# Altium schematic sheet Micromouse_1_2.SchDoc
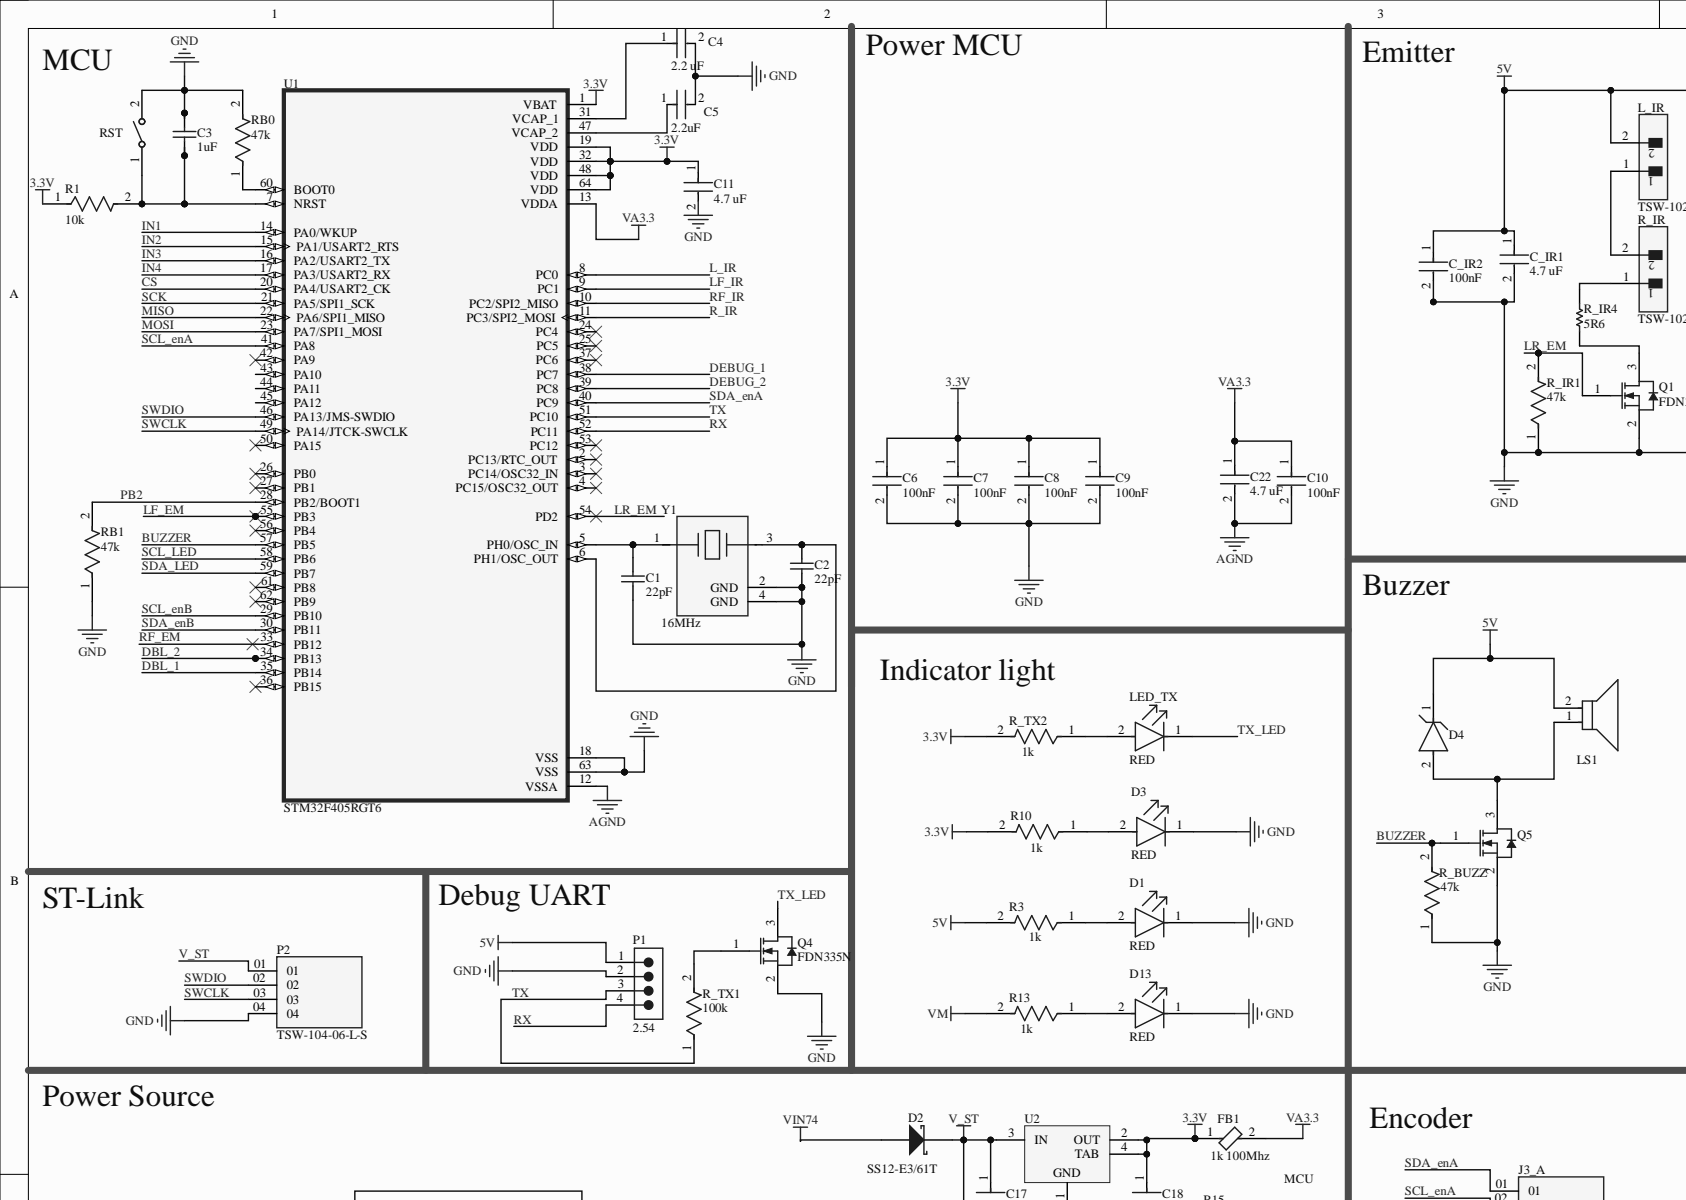
[diagram p0: Micromouse_1_2.SchDoc, top left]
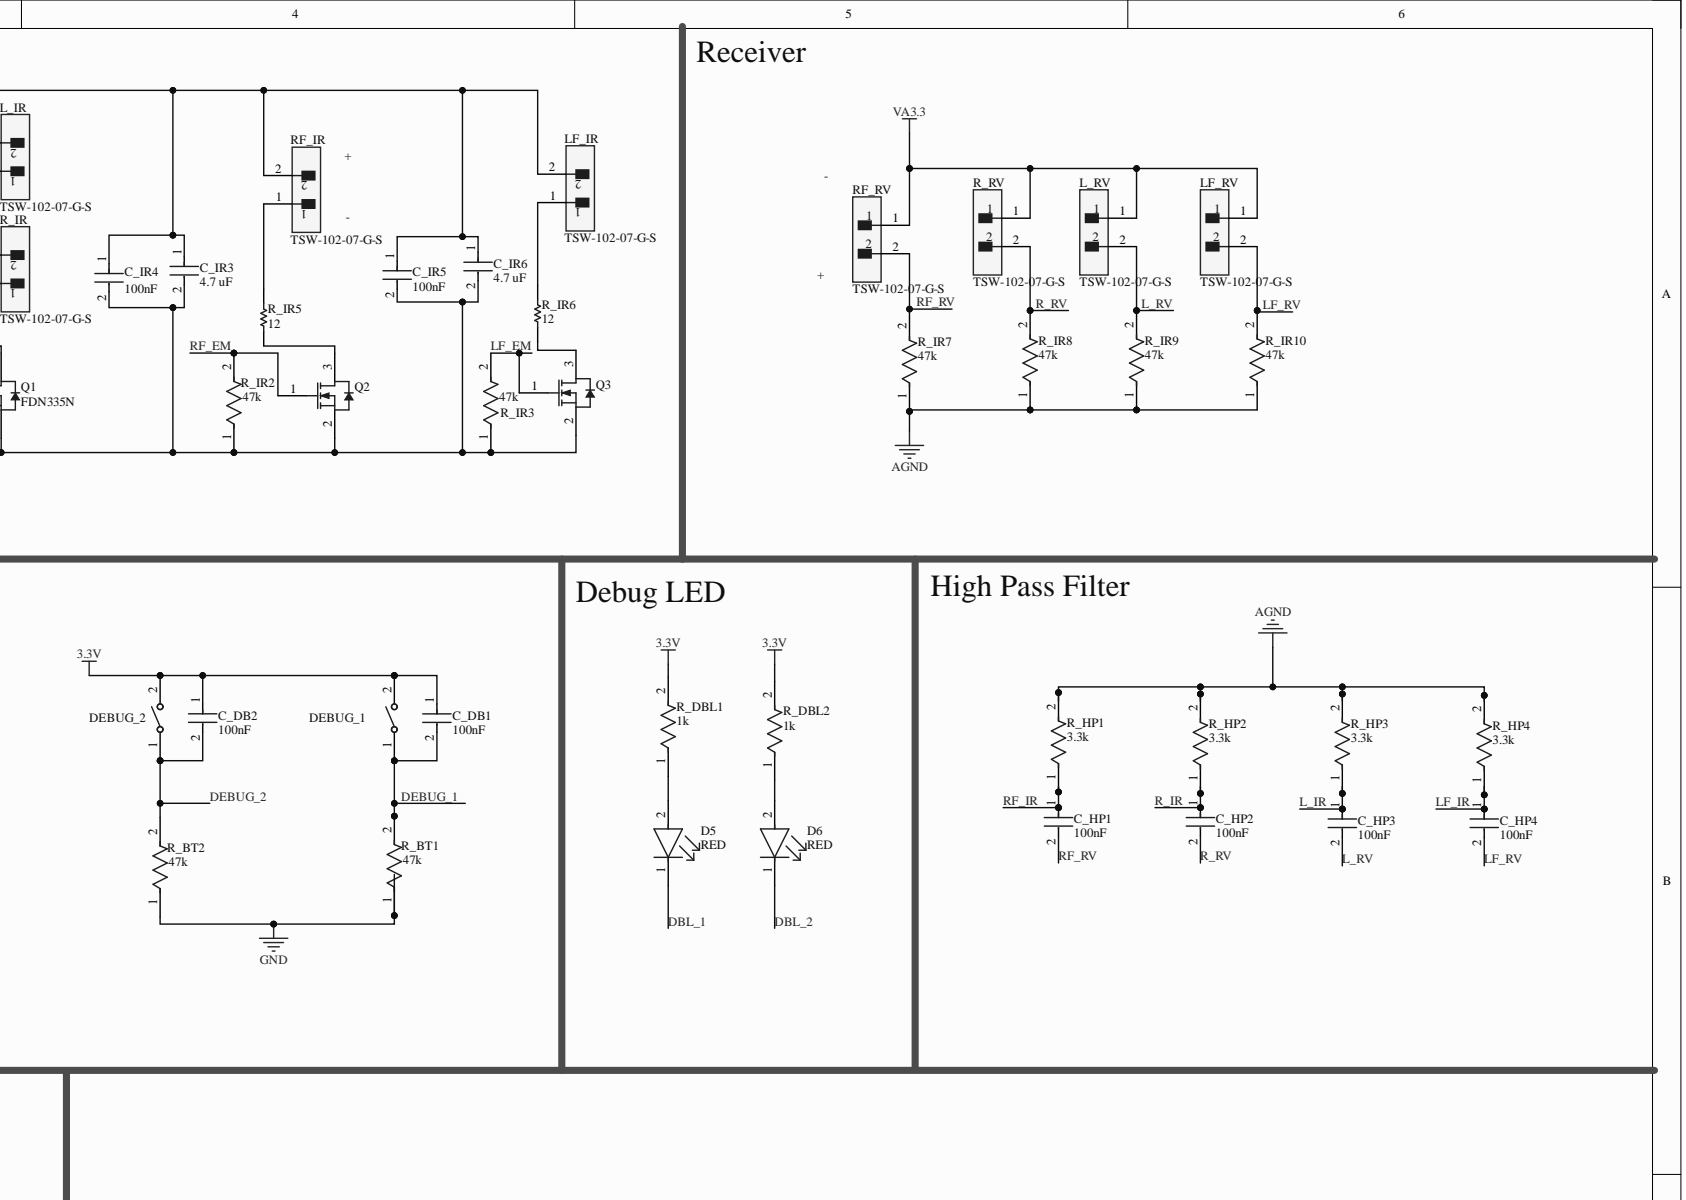
[diagram p1: Micromouse_1_2.SchDoc, top right]
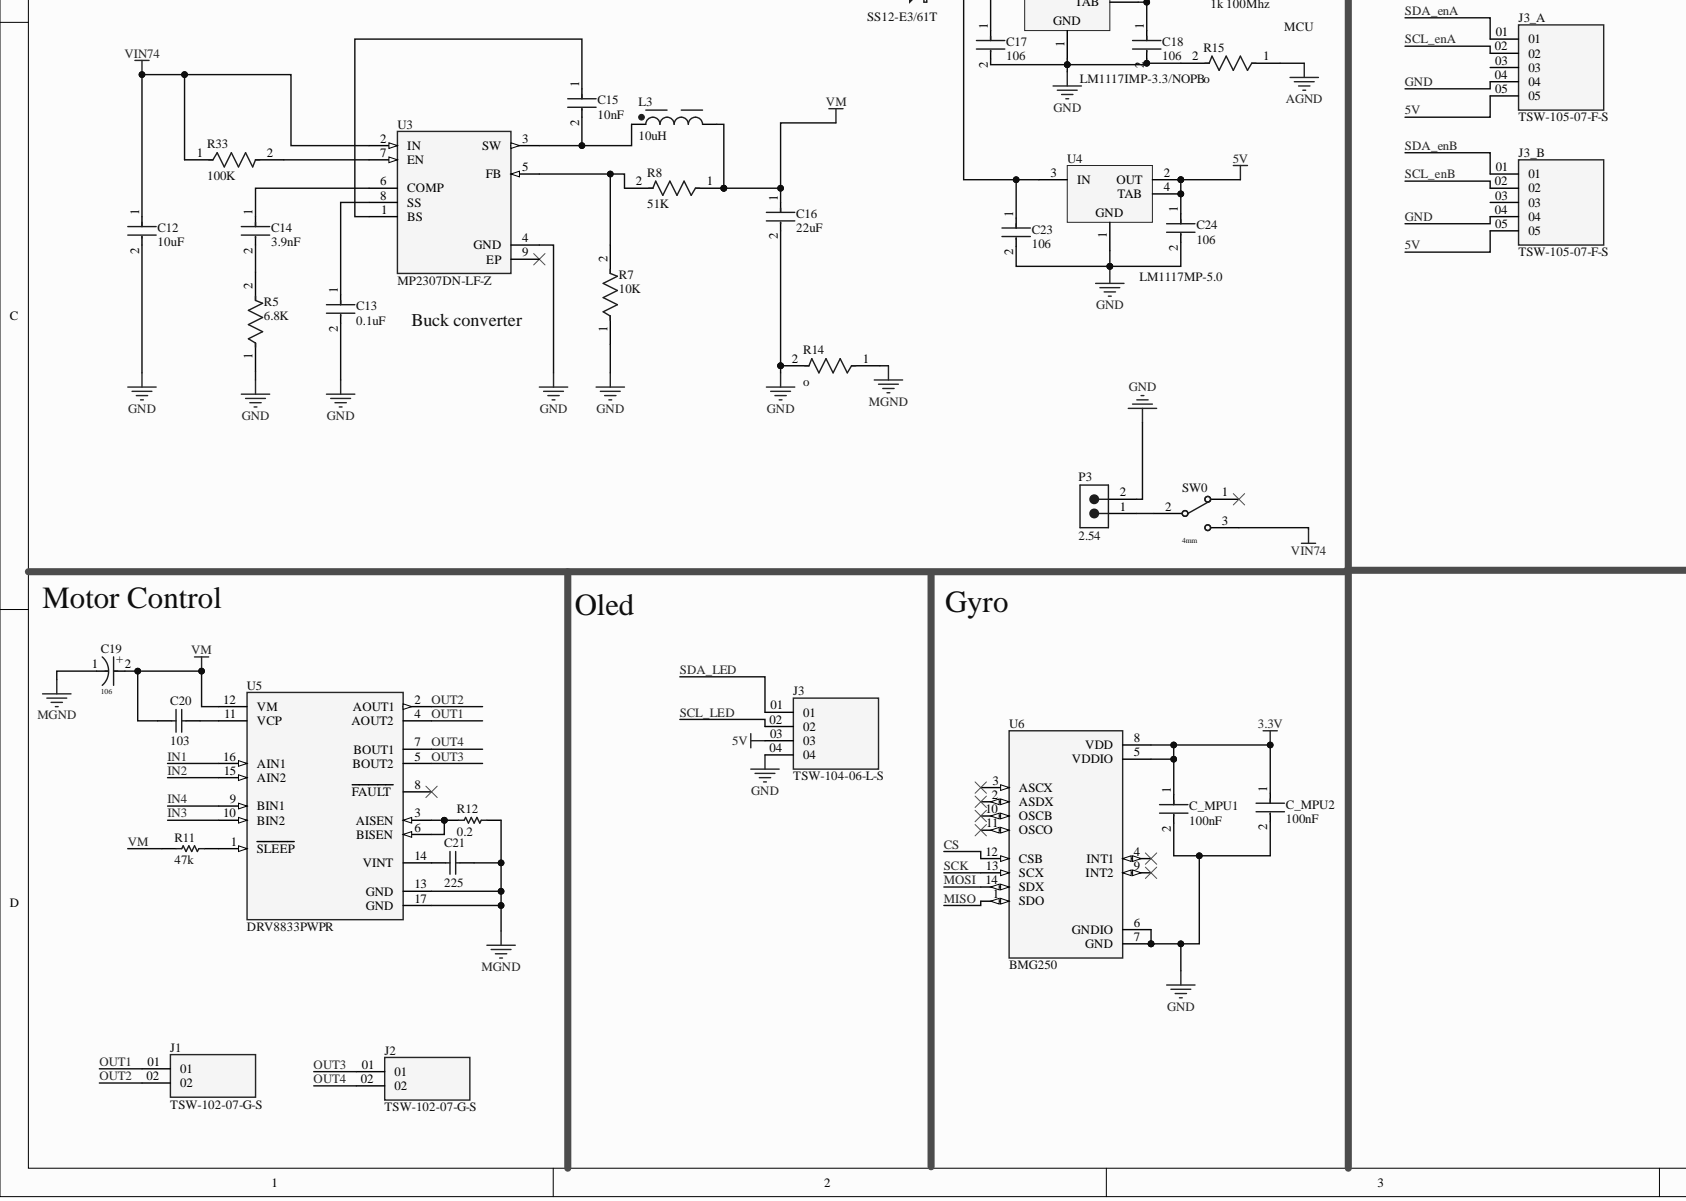
[diagram p2: Micromouse_1_2.SchDoc, bottom left]
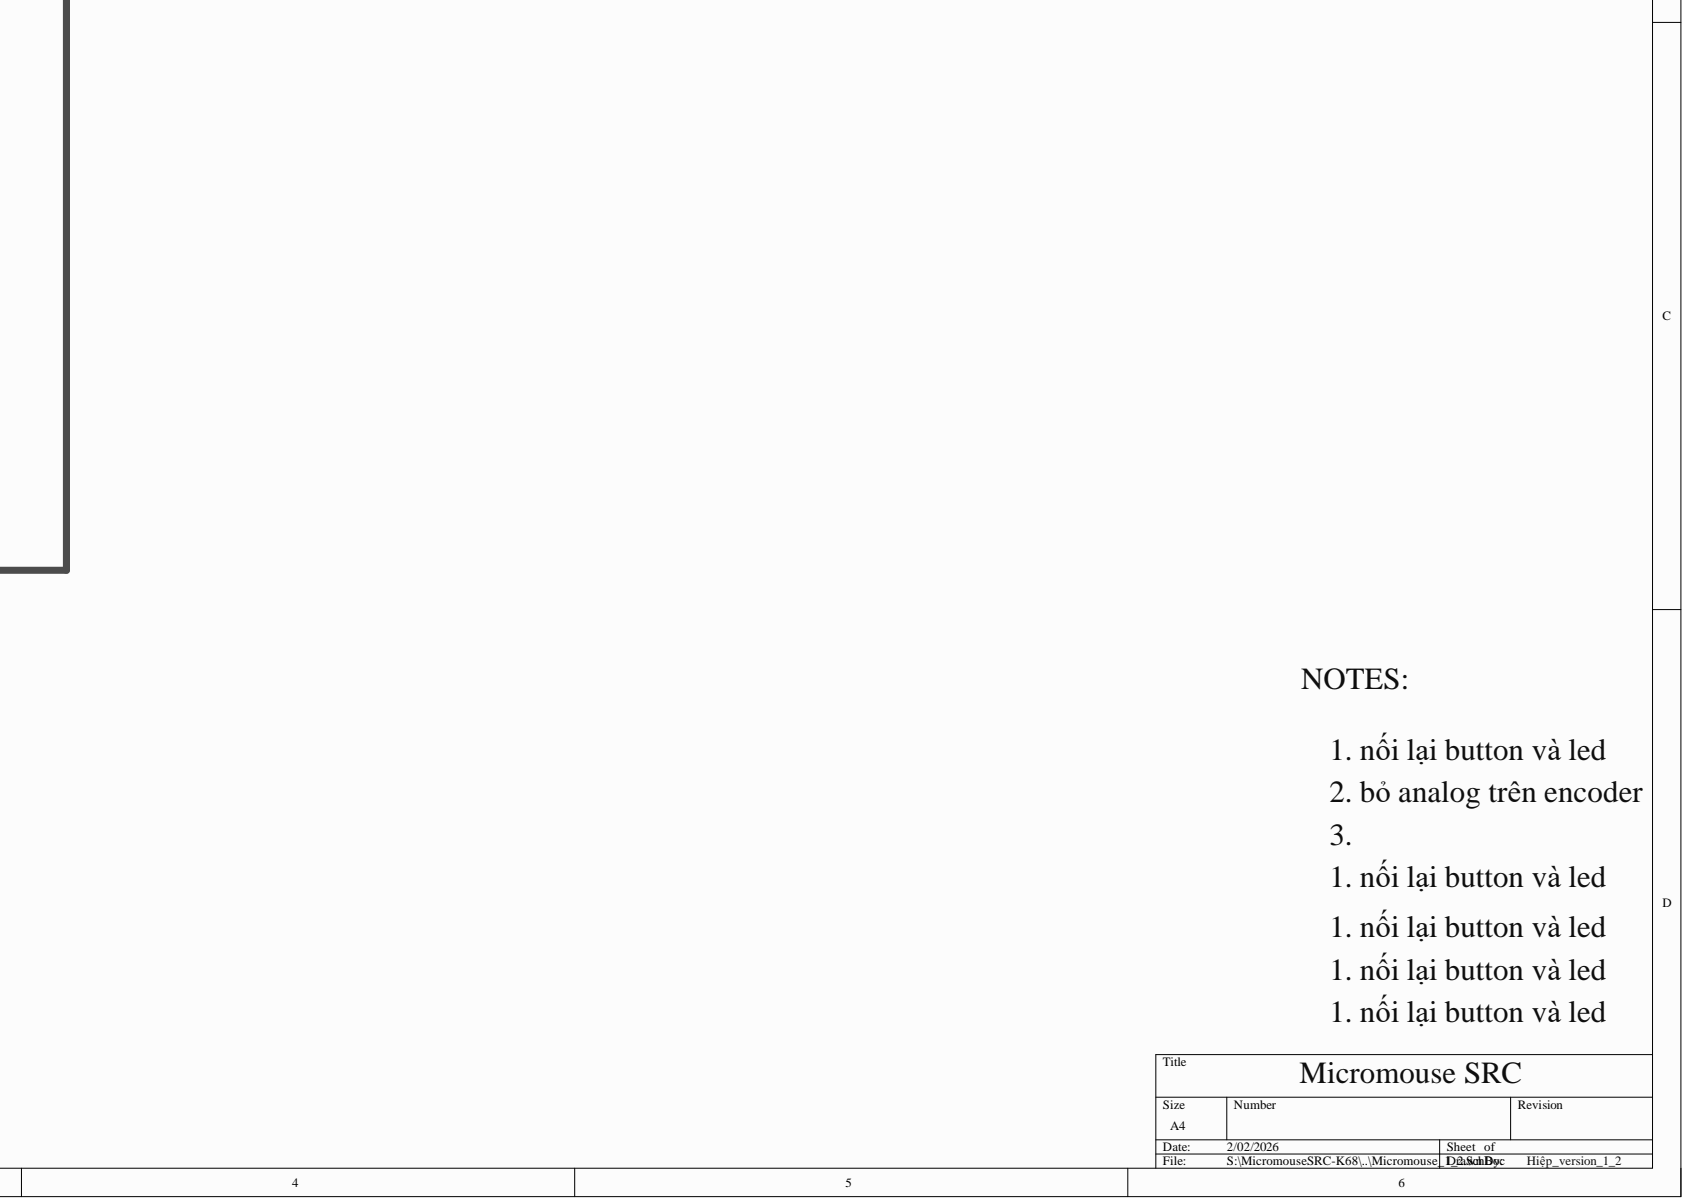
[diagram p3: Micromouse_1_2.SchDoc, bottom right]

## Parts
C1  22pF  [CAPC1608X92X38ML15T08]
C2  22pF  [CAPC1608X92X38ML15T08]
C3  1uF  [CAPC1608X90X35NL15T15]
C4  2.2 uF  [FP-C0603-CG-MFG]
C5  2.2uF  [FP-C0603-CG-MFG]
C6  100nF  [FP-CL10-IPC_C]
C7  100nF  [FP-CL10-IPC_C]
C8  100nF  [FP-CL10-IPC_C]
C9  100nF  [FP-CL10-IPC_C]
C10  100nF  [FP-CL10-IPC_C]
C11  4.7 uF  [FP-C0603-CG-MFG]
C12  10uF  [FP-C1206C-EB-MFG]
C13  0.1uF  [FP-C1206C-EB-MFG]
C14  3.9nF  [FP-C1206C-EB-MFG]
C15  10nF  [FP-C1206C-EB-MFG]
C16  22uF  [FP-C1206C-EB-MFG]
C17  106  [FP-GRT31C-0_2-IPC_A]
C18  106  [FP-GRT31C-0_2-IPC_A]
C19  106  [FP-T491C-MFG]
C20  103  [CAPC2013X88X50LL20T25]
C21  225  [CAPC3216X180X50LL20T25]
C22  4.7 uF  [FP-C0603-CG-MFG]
C23  106  [FP-GRT31C-0_2-IPC_A]
C24  106  [FP-GRT31C-0_2-IPC_A]
C_DB1  100nF  [FP-CL10-IPC_C]
C_DB2  100nF  [FP-CL10-IPC_C]
C_HP1  100nF  [FP-CL10-IPC_C]
C_HP2  100nF  [FP-CL10-IPC_C]
C_HP3  100nF  [FP-CL10-IPC_C]
C_HP4  100nF  [FP-CL10-IPC_C]
C_IR1  4.7 uF  [FP-C0603-CG-MFG]
C_IR2  100nF  [FP-CL10-IPC_C]
C_IR3  4.7 uF  [FP-C0603-CG-MFG]
C_IR4  100nF  [FP-CL10-IPC_C]
C_IR5  100nF  [FP-CL10-IPC_C]
C_IR6  4.7 uF  [FP-C0603-CG-MFG]
C_MPU1  100nF  [FP-CL10-IPC_C]
C_MPU2  100nF  [FP-CL10-IPC_C]
D1  RED  [FP-LTST-C190GKT-MFG]
D2  SS12-E3/61T  [VISH-DO-214AC_V]
D3  RED  [FP-LTST-C190GKT-MFG]
D4  MMSZ5232B  [FP-MA02A-MFG]  (value not drawn)
D5  RED  [FP-LTST-C190GKT-MFG]
D6  RED  [FP-LTST-C190GKT-MFG]
D13  RED  [FP-LTST-C190GKT-MFG]
DEBUG_1  (no value)  [TECO-FSMSMTR_V]
DEBUG_2  (no value)  [TECO-FSMSMTR_V]
FB1  1k 100Mhz  [FP-BLM21-0_2-t0_85-e0_5-IPC_C]
J1  TSW-102-07-G-S  [SAMTEC_TSW-102-07-G-S]
J2  TSW-102-07-G-S  [SAMTEC_TSW-102-07-G-S]
J3  TSW-104-06-L-S  [SAMTEC_TSW-104-06-L-S]
J3_A  TSW-105-07-F-S  [SAMTEC_TSW-105-07-F-S]
J3_B  TSW-105-07-F-S  [SAMTEC_TSW-105-07-F-S]
L3  10uH  [WE-TPC_5818]
L_IR  TSW-102-07-G-S  [FP-TSW-102-07-G-S-MFG]
L_RV  TSW-102-07-G-S  [FP-TSW-102-07-G-S-MFG]
LED_TX  RED  [FP-LTST-C190GKT-MFG]
LF_IR  TSW-102-07-G-S  [FP-TSW-102-07-G-S-MFG]
LF_RV  TSW-102-07-G-S  [FP-TSW-102-07-G-S-MFG]
LS1  MCKPX-G1203A-K4056  [FP-MCKPX-G1203A-K4056-MFG]  (value not drawn)
P1  2.54  [61300411121]
P2  TSW-104-06-L-S  [SAMTEC_TSW-104-06-L-S]
P3  2.54  [61300211121]
Q1  FDN335N  [FAIR-SSOT3L-3_V]
Q2  (no value)  [FAIR-SSOT3L-3_V]
Q3  (no value)  [FAIR-SSOT3L-3_V]
Q4  FDN335N  [FAIR-SSOT3L-3_V]
Q5  (no value)  [FAIR-SSOT3L-3_V]
R1  10k  [FP-CPF0603-MFG]
R3  1k  [FP-CPF0603-MFG]
R5  6.8K  [FP-CRCW0805-e3-IPC_B]
R7  10K  [FP-CRCW0805-e3-IPC_B]
R8  51K  [FP-CRCW0805-e3-IPC_B]
R10  1k  [FP-CPF0603-MFG]
R11  47k  [RESC3216X60X45LL10T20]
R12  0.2  [RESC3216X89X51ML25T25]
R13  1k  [FP-CPF0603-MFG]
R14  o  [FP-TFCR0805-MFG]
R15  o  [FP-TFCR0805-MFG]
R33  100K  [FP-CRCW0805-e3-IPC_B]
R_BT1  47k  [FP-CPF0603-MFG]
R_BT2  47k  [FP-CPF0603-MFG]
R_BUZZ  47k  [FP-CPF0603-MFG]
R_DBL1  1k  [FP-CPF0603-MFG]
R_DBL2  1k  [FP-CPF0603-MFG]
R_HP1  3.3k  [FP-CPF0603-MFG]
R_HP2  3.3k  [FP-CPF0603-MFG]
R_HP3  3.3k  [FP-CPF0603-MFG]
R_HP4  3.3k  [FP-CPF0603-MFG]
R_IR  TSW-102-07-G-S  [FP-TSW-102-07-G-S-MFG]
R_IR1  47k  [FP-CPF0603-MFG]
R_IR2  47k  [FP-CPF0603-MFG]
R_IR3  47k  [FP-CPF0603-MFG]
R_IR4  5R6  [RESC2013X50X30NL20T20]
R_IR5  12  [RESC2013X50X30NL20T20]
R_IR6  12  [RESC2013X50X30NL20T20]
R_IR7  47k  [FP-CPF0603-MFG]
R_IR8  47k  [FP-CPF0603-MFG]
R_IR9  47k  [FP-CPF0603-MFG]
R_IR10  47k  [FP-CPF0603-MFG]
R_RV  TSW-102-07-G-S  [FP-TSW-102-07-G-S-MFG]
R_TX1  100k  [FP-CPF0603-MFG]
R_TX2  1k  [FP-CPF0603-MFG]
RB0  47k  [FP-CPF0603-MFG]
RB1  47k  [FP-CPF0603-MFG]
RF_IR  TSW-102-07-G-S  [FP-TSW-102-07-G-S-MFG]
RF_RV  TSW-102-07-G-S  [FP-TSW-102-07-G-S-MFG]
RST  (no value)  [TECO-FSMSMTR_V]
SW0  4mm  [ESWI-EG1218_V]
U1  STM32F405RGT6  [QFP50P1200X1200X160-64N]
U2  LM1117IMP-3.3/NOPB  [DCY0004A_N]
U3  MP2307DN-LF-Z  [SOIC127P600X170-9N]
U4  LM1117MP-5.0  [MP04A_N]
U5  DRV8833PWPR  [FP-PWP0016C-IPC_B]
U6  BMG250  [XDCR_BMG250]
Y1  16MHz  [FP-ABM10W-MFG]

## Nets
3.3V: C6.1 C7.1 C8.1 C9.1 C11.1 C18.1 C_DB1.1 C_DB2.1 C_MPU1.1 C_MPU2.1 DEBUG_1.2 DEBUG_2.2 FB1.1 R1.1 R10.2 R_DBL1.2 R_DBL2.2 R_TX2.2 U1.1(VBAT) U1.19(VDD) U1.32(VDD) U1.48(VDD) U1.64(VDD) U2.2(OUT) U2.4(TAB) U6.5(VDDIO) U6.8(VDD)
AGND: C10.2 C22.2 R15.1 R_HP1.2 R_HP2.2 R_HP3.2 R_HP4.2 R_IR7.1 R_IR8.1 R_IR9.1 R_IR10.1 U1.12(VSSA)
MGND: C19.1 C21.2 R12.2 R14.1 U5.13(GND) U5.17(GND)
VA3.3: C10.1 C22.1 FB1.2 L_RV.1 LF_RV.1 R_RV.1 RF_RV.1 U1.13(VDDA)
VIN74: C12.1 D2.1 R33.1 SW0.3 U3.2(IN)
5V: C24.1 C_IR1.1 C_IR2.1 C_IR3.1 C_IR4.1 C_IR5.1 C_IR6.1 D4.1 J3.03 J3_A.05 J3_B.05 L_IR.2 LF_IR.2 LS1.2 P1.1 R3.2 RF_IR.2 U4.2(OUT) U4.4(TAB)
BUZZER: Q5.1 R_BUZZ.2 U1.57(PB5)
CS: U1.20(PA4/USART2_CK) U6.12(CSB)
DBL_1: D5.1 U1.35(PB14)
DBL_2: D6.1 U1.34(PB13)
DEBUG_1: C_DB1.2 DEBUG_1.1 R_BT1.2 U1.38(PC7)
DEBUG_2: C_DB2.2 DEBUG_2.1 R_BT2.2 U1.39(PC8)
GND: C1.1 C2.1 C3.2 C4.2 C5.2 C6.2 C7.2 C8.2 C9.2 C11.2 C12.2 C13.2 C16.2 C17.2 C18.2 C23.2 C24.2 C_IR1.2 C_IR2.2 C_IR3.2 C_IR4.2 C_IR5.2 C_IR6.2 C_MPU1.2 C_MPU2.2 D1.1 D3.1 D13.1 J3.04 J3_A.04 J3_B.04 P1.2 P2.04 P3.2 Q1.2 Q2.2 Q3.2 Q4.2 Q5.2 R5.1 R7.1 R14.2 R15.2 R_BT1.1 R_BT2.1 R_BUZZ.1 R_IR1.1 R_IR2.1 R_IR3.1 RB0.2 RB1.1 RST.2 U1.18(VSS) U1.63(VSS) U2.1 U3.4(GND) U4.1 U6.6(GNDIO) U6.7(GND) Y1.2(GND) Y1.4(GND)
IN1: U1.14(PA0/WKUP) U5.16(AIN1)
IN2: U1.15(PA1/USART2_RTS) U5.15(AIN2)
IN3: U1.16(PA2/USART2_TX) U5.10(BIN2)
IN4: U1.17(PA3/USART2_RX) U5.9(BIN1)
L_IR: C_HP3.1 R_HP3.1 U1.8(PC0)
L_RV: C_HP3.2 L_RV.2 R_IR9.2
LF_EM: Q3.1 R_IR3.2 U1.55(PB3)
LF_IR: C_HP4.1 R_HP4.1 U1.9(PC1)
LF_RV: C_HP4.2 LF_RV.2 R_IR10.2
LR_EM: Q1.1 R_IR1.2 U1.54(PD2)
MISO: U1.22(PA6/SPI1_MISO) U6.1(SDO)
MOSI: U1.23(PA7/SPI1_MOSI) U6.14(SDX)
OUT1: J1.01 U5.4(AOUT2)
OUT2: J1.02 U5.2(AOUT1)
OUT3: J2.01 U5.5(BOUT2)
OUT4: J2.02 U5.7(BOUT1)
PB2: RB1.2 U1.28(PB2/BOOT1)
R_IR: C_HP2.1 R_HP2.1 U1.11(PC3/SPI2_MOSI)
R_RV: C_HP2.2 R_IR8.2 R_RV.2
RF_EM: Q2.1 R_IR2.2 U1.33(PB12)
RF_IR: C_HP1.1 R_HP1.1 U1.10(PC2/SPI2_MISO)
RF_RV: C_HP1.2 R_IR7.2 RF_RV.2
RX: P1.4 U1.52(PC11)
SCK: U1.21(PA5/SPI1_SCK) U6.13(SCX)
SCL_enA: J3_A.02 U1.41(PA8)
SCL_enB: J3_B.02 U1.29(PB10)
SCL_LED: J3.02 U1.58(PB6)
SDA_enA: J3_A.01 U1.40(PC9)
SDA_enB: J3_B.01 U1.30(PB11)
SDA_LED: J3.01 U1.59(PB7)
SWCLK: P2.03 U1.49(PA14/JTCK-SWCLK)
SWDIO: P2.02 U1.46(PA13/JMS-SWDIO)
TX: P1.3 R_TX1.1 U1.51(PC10)
TX_LED: LED_TX.1 Q4.3
V_ST: C17.1 C23.1 D2.2 P2.01 U2.3(IN) U4.3(IN)
VM: C16.1 C19.2 C20.1 L3.2 R8.1 R11.1 R13.2 U5.12(VM)
NetC1_2: C1.2 U1.5(PH0/OSC_IN) Y1.1
NetC2_2: C2.2 U1.6(PH1/OSC_OUT) Y1.3
NetC3_1: C3.1 R1.2 RST.1 U1.7(NRST)
NetC4_1: C4.1 U1.31(VCAP_1)
NetC5_1: C5.1 U1.47(VCAP_2)
NetC13_1: C13.1 U3.8(SS)
NetC14_1: C14.1 U3.6(COMP)
NetC14_2: C14.2 R5.2
NetC15_1: C15.1 U3.1(BS)
NetC15_2: C15.2 L3.1 U3.3(SW)
NetC20_2: C20.2 U5.11(VCP)
NetC21_1: C21.1 U5.14(VINT)
NetD1_2: D1.2 R3.1
NetD3_2: D3.2 R10.1
NetD4_2: D4.2 LS1.1 Q5.3
NetD5_2: D5.2 R_DBL1.1
NetD6_2: D6.2 R_DBL2.1
NetD13_2: D13.2 R13.1
NetL_IR_1: L_IR.1 R_IR.2
NetLED_TX_2: LED_TX.2 R_TX2.1
NetLF_IR_1: LF_IR.1 R_IR6.2
NetP3_1: P3.1 SW0.2
NetQ1_3: Q1.3 R_IR4.1
NetQ2_3: Q2.3 R_IR5.1
NetQ3_3: Q3.3 R_IR6.1
NetQ4_1: Q4.1 R_TX1.2
NetR7_2: R7.2 R8.2 U3.5(FB)
NetR11_2: R11.2 U5.1(SLEEP)
NetR12_1: R12.1 U5.3(AISEN) U5.6(BISEN)
NetR33_2: R33.2 U3.7(EN)
NetR_IR4_2: R_IR.1 R_IR4.2
NetR_IR5_2: R_IR5.2 RF_IR.1
NetRB0_1: RB0.1 U1.60(BOOT0)

Not connected: J3_A 03; J3_B 03; SW0 1; U1 2(PC13/RTC_OUT) 3(PC14/OSC32_IN) 4(PC15/OSC32_OUT) 24(PC4) 25(PC5) 26(PB0) 27(PB1) 36(PB15) 37(PC6) 42(PA9) 43(PA10) 44(PA11) 45(PA12) 50(PA15) 53(PC12) 56(PB4) 61(PB8) 62(PB9); U3 9(EP); U5 8(FAULT); U6 2(ASDX) 3(ASCX) 4(INT1) 9(INT2) 10(OSCB) 11(OSCO)

## Library data of the parts
C1 C2 = CMP-1035-00826-2: Manufacturer: Vitramon; Part Number: VJ0603A220FXBAC; Component Kind: Standard; Library Name: Altium Content Vault
C3 = CMP-2006-04534-1: Manufacturer: AVX Interconnect / Elco; Part Number: 0603YD105MAT2A; Component Kind: Standard; Library Name: Altium Content Vault
C4 C5 C11 C22 = CMP-2006-02256-2: CAP CER 4.7UF 6.3V X5R 0603; Manufacturer: Kemet; Manufacturer Part Number: C0603C475K9PACTU; Material: Ceramic; Temperature Coefficient: 15%; Tolerance: 10%; Automotive: No; Capacitance: 4.7uF; Category: Cap; Component Kind: Standard; ComponentLink2Description: Datasheet; ComponentLink2URL: https://content.kemet.com/datasheets/KEM_C1006_X5R_SMD.pdf; Device Class L1: Passive Components; Device Class L2: Capacitors; Device Class L3: Ceramic Capacitors; Digikey Description: CAP CER 4.7UF 6.3V X5R 0603; Height: 0.9mm; Ipc Land Pattern Name: CAPC16080X80; Lead Free: Yes; Library Name: Altium Content Vault; Mouser Description: Multilayer Ceramic Capacitors MLCC - SMD/SMT 6.3volts 4.7uF X5R 10%; Octopart: https://octopart.com/c0603c475k9pactu-kemet-104242; Package: C0603-CG; RoHS: TRUE; Temperature: -55°C to +85°C; Temperature Characteristic: X5R; Temperature Range High: +85°C; Temperature Range Low: -55°C; Value: 4.7uF; Voltage Rating: 6.3V
C6-C10 C_DB1 C_DB2 C_HP1-C_HP4 C_IR2 C_IR4 C_IR5 C_MPU1 C_MPU2 = CMP-13271-001423-1: Cap Ceramic 100nF 25V X7R ±10% Pad SMD 0603 +125°C T/R; Capacitance: 100nF; Case Code (Imperial): 0603; Case Code (Metric): 1608; Case/Package: 0603; Component Kind: Standard; Depth: 0.8mm; Dielectric: X7R; Height: 0.8mm; Length: 1.6mm; Library Name: Altium Content Vault; Max Operating Temperature: 125°C; Min Operating Temperature: -55°C; Mount: Surface Mount; Packaging: Tape and Reel; Radiation Hardening: No; Thickness: 0.035inch; Tolerance: 10%; Voltage Rating: 25V; Width: 0.031inch
C12 = CMP-1037-00646-2: C1206 10 uF C0G 5.00% 50 V; Manufacturer: KEMET; Manufacturer Part Number: C1206C100J5GAC; Automotive Grade: Grade 0; Capacitance: 10 pF; Material: Ceramic; Temperature Coefficient: 15%; Tolerance: 0.05; Value: 10 pF; Voltage Rating: 50 V; Automotive: Yes; Category: Cap; Component Kind: Standard; ComponentLink2Description: Datasheet; ComponentLink2URL: https://content.kemet.com/datasheets/KEM_C1007_X8R_ULTRA_150C_SMD.pdf; Device Class L1: Passive Components; Device Class L2: Capacitors; Device Class L3: Ceramic Capacitors; Height: 0.88mm; Ipc Land Pattern Name: CAPC320160X78; Lead Free: Yes; Library Name: Altium Content Vault; Mouser Description: Multilayer Ceramic Capacitors MLCC - SMD/SMT 50volts 10pF 5% C0G; Octopart: https://octopart.com/c1206c100j5gac-kemet-1357555; Package: C1206C-EB; RoHS: TRUE; Temperature: -55°C to +150°C; Temperature Characteristic: C0G; Temperature Range High: +150°C; Temperature Range Low: -55°C
C13 C15 C16 = CMP-1037-00646-2: C1206 10 pF C0G 5.00% 50 V; Manufacturer: KEMET; Manufacturer Part Number: C1206C100J5GAC; Automotive Grade: Grade 0; Capacitance: 10 pF; Material: Ceramic; Temperature Coefficient: 15%; Tolerance: 0.05; Value: 10 pF; Voltage Rating: 50 V; Automotive: Yes; Category: Cap; Component Kind: Standard; ComponentLink2Description: Datasheet; ComponentLink2URL: https://content.kemet.com/datasheets/KEM_C1007_X8R_ULTRA_150C_SMD.pdf; Device Class L1: Passive Components; Device Class L2: Capacitors; Device Class L3: Ceramic Capacitors; Height: 0.88mm; Ipc Land Pattern Name: CAPC320160X78; Lead Free: Yes; Library Name: Altium Content Vault; Mouser Description: Multilayer Ceramic Capacitors MLCC - SMD/SMT 50volts 10pF 5% C0G; Octopart: https://octopart.com/c1206c100j5gac-kemet-1357555; Package: C1206C-EB; RoHS: TRUE; Temperature: -55°C to +150°C; Temperature Characteristic: C0G; Temperature Range High: +150°C; Temperature Range Low: -55°C
C14 = CMP-1037-00646-2: C1206 4.8nF C0G 5.00% 50 V; Manufacturer: KEMET; Manufacturer Part Number: C1206C100J5GAC; Automotive Grade: Grade 0; Capacitance: 10 pF; Material: Ceramic; Temperature Coefficient: 15%; Tolerance: 0.05; Value: 10 pF; Voltage Rating: 50 V; Automotive: Yes; Category: Cap; Component Kind: Standard; ComponentLink2Description: Datasheet; ComponentLink2URL: https://content.kemet.com/datasheets/KEM_C1007_X8R_ULTRA_150C_SMD.pdf; Device Class L1: Passive Components; Device Class L2: Capacitors; Device Class L3: Ceramic Capacitors; Height: 0.88mm; Ipc Land Pattern Name: CAPC320160X78; Lead Free: Yes; Library Name: Altium Content Vault; Mouser Description: Multilayer Ceramic Capacitors MLCC - SMD/SMT 50volts 10pF 5% C0G; Octopart: https://octopart.com/c1206c100j5gac-kemet-1357555; Package: C1206C-EB; RoHS: TRUE; Temperature: -55°C to +150°C; Temperature Characteristic: C0G; Temperature Range High: +150°C; Temperature Range Low: -55°C
C17 C18 C23 C24 = CMP-06035-000076-2: Multi-Layer Ceramic Capacitor 10uF 50V X5R ±10% 1206 Emboss T/R; Capacitance: 10uF; Case Code (Imperial): 1206; Case Code (Metric): 3216; Case/Package: 1206; Component Kind: Standard; Dielectric: X5R; Length: 0.126inch; Library Name: Altium Content Vault; Max Operating Temperature: 85°C; Min Operating Temperature: -55°C; Mount: Surface Mount; Packaging: Cut Tape; Ratings: AEC-Q200; Thickness: 0.071inch; Tolerance: 10%; Voltage Rating: 50V; Voltage Rating (DC): 50V; Width: 0.063inch
C19 = CMP-03017-000001-1: CAP TANT 10UF 10% 25V 2312; Manufacturer: Kemet; Manufacturer Part Number: T491C106K025AT; Dissipation Factor: 6%; Esr: 1.5Ω; Leakage Current: 2.5uA; Life: 2000Hours; Material: Tantalum; Ripple Current: 271mA; Standoff Height: 0mm; Automotive: No; Capacitance: 10uF; Category: Cap; Component Kind: Standard; ComponentLink2Description: Datasheet; ComponentLink2URL: https://content.kemet.com/datasheets/KEM_T2005_T491.pdf; Device Class L1: Passive Components; Device Class L2: Capacitors; Device Class L3: Tantalum Capacitors; Digikey Description: CAP TANT 10UF 10% 25V 2312; Height: 2.8mm; Lead Free: Yes; Library Name: Altium Content Vault; Mouser Description: Tantalum Capacitors - Solid SMD 25V 10uF 10% C; Octopart: https://octopart.com/t491c106k025at-kemet-126002; Package: T491C; RoHS: TRUE; Temperature: -55°C to +125°C; Temperature Range High: +125°C; Temperature Range Low: -55°C; Tolerance: 10%; Value: 10uF; Voltage Rating: 25V
C20 = CMP-2007-00266-1: Manufacturer: Kemet; Part Number: C0805C103K5RACTU; Component Kind: Standard; Library Name: Altium Content Vault
C21 = CMP-1037-04831-2: Chip Capacitor, 2.2 uF, +/- 10%, 50 V, -55 to 125 degC, 1206 (3216 Metric), RoHS, Tape and Reel; Brand: Kemet; Capacitance: 2.2uF; Capacitance - nF: 2200nF; Case Height: 1.6mm; Case Length: 3.2mm; Class: Class1; Dielectric: X7R; Temperature Coefficient / Code: +/-15%; Type: SMDMLCCX7R6.3-250VDCCommericalGrade; Voltage Rating: 50V; Case Code - in: 1206; Case Code - mm: 3216; Case Width: 1.6mm; Component Kind: Standard; Datasheet URL: http://www.kemet.com/docfinder?Partnumber=C1206C225K5RACTU; Datasheet Version: 02/2016; Dimensions: 1.6mmWx3.2mmLx1.6mmH; Factory Pack Quantity: 2000; Library Name: Altium Content Vault; Manufacturer: Kemet; Manufacturer URL: http://www.kemet.com/; Maximum Operating Temperature: +125C; Minimum Operating Temperature: -55C; Mounting Technology: SurfaceMount; Operating Temperature Range: -55Cto+125C; Package / Case: 1206(3216metric); Package Description: 2-Pin Surface Mount Device, Body 3.2 x 1.6 mm; Package Reference: 1206; Packaging: Reel; Product: GeneralTypeMLCCs; Product Category: MultilayerCeramicCapacitorsMLCC-SMD/SMT; RoHS: Details; Series: C1206C; Termination Style: SMD/SMT; Tolerance: 10%; Voltage Rating DC: 50V
C_IR1 C_IR3 C_IR6 = CMP-2006-02256-2: CAP CER 4.7UF 6.3V X5R 0603; Manufacturer: Kemet; Manufacturer Part Number: C0603C475K9PACTU; Temperature Coefficient: 15%; Tolerance: 10%; Automotive: No; Capacitance: 4.7uF; Category: Cap; Component Kind: Standard; ComponentLink2Description: Datasheet; ComponentLink2URL: https://content.kemet.com/datasheets/KEM_C1006_X5R_SMD.pdf; Device Class L1: Passive Components; Device Class L2: Capacitors; Device Class L3: Ceramic Capacitors; Digikey Description: CAP CER 4.7UF 6.3V X5R 0603; Height: 0.9mm; Ipc Land Pattern Name: CAPC16080X80; Lead Free: Yes; Library Name: Altium Content Vault; Material: Ceramic; Mouser Description: Multilayer Ceramic Capacitors MLCC - SMD/SMT 6.3volts 4.7uF X5R 10%; Octopart: https://octopart.com/c0603c475k9pactu-kemet-104242; Package: C0603-CG; RoHS: TRUE; Temperature: -55°C to +85°C; Temperature Characteristic: X5R; Temperature Range High: +85°C; Temperature Range Low: -55°C; Value: 4.7uF; Voltage Rating: 6.3V
D1 D3 D5 D6 D13 LED_TX = CMP-22017-000005-2: LED GREEN CLEAR CHIP SMD; Dominant Wavelength: 569nm; Forward Current: 30mA; Forward Voltage: 2.1V; Lens Color: Colorless; Luminous Intensity: 6mcd; Number of Elements: 1; Power Dissipation: 100mW; Reverse Voltage: 5V; Test Current: 10mA; Viewing Angle: 130°; Voltage: 2V; Wavelength: 565nm; Case Code (Imperial): 0603; Case Code (Metric): 1608; Case/Package: 0603; Color: Green; Component Kind: Standard; Depth: 0.8mm; Height: 0.8mm; Illumination Color: Green; Lead Free: Lead Free; Length: 1.6mm; Lens Style: Clear; Lens Transparency: Clear; Library Name: Altium Content Vault; Max Operating Temperature: 85°C; Min Operating Temperature: -55°C; Mount: Surface Mount; Number of LEDs: 1; Number of Pins: 2; Package Quantity: 1; Packaging: Tape and Reel; Power Rating: 100mW; Radiation Hardening: No; Width: 0.8mm
D2 = CMP-004-00017-2: Schottky Barrier Rectifier, 20 V, 1 A, -65 to 150 degC, 2-Pin DO-214AC (SMA), RoHS, Tape and Reel; Manufacturer: Vishay Semiconductor; Forward Current: 1A; Forward Voltage: 500mV; Max Surge Current: 40A; Peak Reverse Current: 6mA; Case/Package: SMA; Component Kind: Standard; Datasheet URL: http://www.vishay.com/docs/88746/ss12.pdf; Datasheet Version: Aug-15; Manufacturer URL: http://www.vishay.com/; Max Operating Temperature: 125°C; Min Operating Temperature: -65°C; Mounting Technology: SM; Package Description: 2-Pin Surface Mount Device, Body 4.245 x 2.665 mm; Package Quantity: 1800; Package Reference: DO-214AC (SMA); Packaging: TapeandReel; Pins: 2; RoHS Compliant: True; Library Name: Nguyen Hai Son
D4 = CMP-00278-11829623-1: MMSZ5232B MMSZ523 Series 500 mW 5.6 V 10 mA SMT Half-Watt Zener Diode SOD-123; Element Configuration: Single; ESD Protection: No; Forward Voltage: 900mV; Impedance: 11Ω; Max Junction Temperature (Tj): 150°C; Max Power Dissipation: 500mW; Max Reverse Leakage Current: 5µA; Reverse Voltage: 3V; Test Current: 20mA; Tolerance: 5%; Voltage Rating (DC): 5.6V; Component Kind: Standard; Contact Plating: Tin; Height: 1.28mm; Lead Free: Lead Free; Length: 2.85mm; Library Name: Altium Content Vault; Max Operating Temperature: 150°C; Min Operating Temperature: -55°C; Mount: Surface Mount; NexarPartId: 11829623; Number of Pins: 2; Packaging: Cut Tape; Peak Reverse Current: 5µA; Power Dissipation: 500mW; Power Rating: 500mW; Radiation Hardening: No; REACH SVHC: No SVHC; RoHS: Compliant; Voltage Tolerance: 5%; Weight: 30mg; Width: 1.8mm; Working Voltage: 5.6V; Zener Current: 20mA; Zener Voltage: 5.6V
DEBUG_1 DEBUG_2 RST = CMP-2000-07501-1: FSMSM Push Button Switch, 50 mA, -35 to 85 degC, 2-Pin SMD, RoHS, Tape and Reel; Manufacturer: TE Connectivity; Actuator Length: 1.07mm; Actuator Material: Polyamide; Case\/Package: SMD/SMT; Contact Current Rating: 50mA; Contact Resistance: 100mOhm; Electrical Life: 20000Cycles; Illumination Type: Illuminated; Max Voltage Rating (DC): 12V; Operating Force: 180gf; Switch Travel: 0.25mm; Throw Configuration: SPST; Voltage Rating (DC): 24V; Component Kind: Standard; Contact Material: Brass; Contact Plating: Silver; Current Rating: 50mA; Datasheet URL: http://www.te.com/commerce/DocumentDelivery/DDEController?Action=showdoc&DocId=Customer+Drawing%7F1437566-3%7FC2%7Fpdf%7FEnglish%7FENG_CD_1437566-3_C2_1437566_3_1_c.dwg.pdf%7F1437566-4; Datasheet Version: Rev. C2, 08/2014; ELV: Compliant; Lead Free: LeadFree; Library Name: Altium Content Vault; Manufacturer URL: http://www.te.com/; Max Operating Temperature: 85degC; Mechanical Life: 20000Cycles; Min Operating Temperature: -35degC; Mount: SurfaceMount; Mounting Technology: SurfaceMount; Orientation: Straight; Package Description: 2-Pin Surface Mount Device, Body 6 x 3.5 mm, Pitch 9.19 mm; Package Quantity: 1800; Package Reference: FSMSMTR; Packaging: TapeandReel; REACH SVHC: Unknown; RoHSCompliant: true; Termination: GullWing
FB1 = CMP-06046-002606-1: Chip Ferrite Bead, 0805, 60Ω @ 100MHz, 0.02Ω, 25%, 1.9A; Component Kind: Standard; Library Name: Altium Content Vault; RoHS Compliant: No
J1 J2 = TSW-102-07-G-S: MF: Samtec; Availability: Unavailable; Component Kind: Standard; Library Name: TSW-102-07-G-S.IntLib; MP: TSW-102-07-G-S; Package: None; Price: None
J3 P2 = CMP-034-000000-1: Availability: Unavailable; Component Kind: Standard; Component Type: Standard; Design Item ID: TSW-104-06-L-S; MP: TSW-104-06-L-S; Package: None; Pin Count: 4; Price: None; Source: TSW-104-06-L-S.SchLib; Library Name: Nguyen Hai Son
J3_A J3_B = CMP-023-000001-1: MF: Samtec; Availability: Unavailable; Component Kind: Standard; Component Type: Standard; Design Item ID: TSW-105-07-F-S; MP: TSW-105-07-F-S; Package: None; Pin Count: 5; Price: None; Source: TSW-105-07-F-S.SchLib; Library Name: Vũ Hiệp
L3 = CMP-0227-00416-3: NexarPartId: 22866864; Core Material: Ferrite; Current Rating: 1.45A; DC Resistance (DCR): 70mΩ; Inductance: 6.2µH; Inductance Tolerance: 30%; Saturation Current: 1.6A; Self Resonant Frequency: 45MHz; Shielding: Shielded; Test Frequency: 100kHz; Ambient Temperature Range High: 95°C; Ambient Temperature Range Low: -40°C; Case Code (Imperial): 2323; Case Code (Metric): 5858; Case/Package: 2323; Component Kind: Standard; Composition: Wirewound; Depth: 5.8mm; Height: 1.8mm; Height - Seated (Max): 2.0066mm; Length: 5.8mm; Library Name: Altium Content Vault; Max DC Current: 1.45A; Max Operating Temperature: 125°C; Min Operating Temperature: -40°C; Mount: Surface Mount; Number of Pins: 2; Packaging: Cut Tape (CT); REACH SVHC: Unknown; RMS Current (Irms): 1.45A; RoHS: Compliant; Termination: SMD/SMT; Tolerance: 30%; Weight: 248.001628mg; Width: 5.8mm
L_IR L_RV LF_IR LF_RV R_IR R_RV RF_IR RF_RV = CMP-02766-000084-1: CONN HEADER VERT 2POS 2.54MM; Manufacturer: Samtec; Conn Gender: Male; Conn Orientation: Straight; Current Rating: 6.3A; Number Of Contacts: 2; Voltage Rating: 550V; Automotive: No; Category: Conn; Component Kind: Standard; ComponentLink2Description: Datasheet; ComponentLink2URL: http://suddendocs.samtec.com/catalog_english/tsw_th.pdf; Contact Material: Gold,Nickel; Device Class L1: Connectors; Device Class L2: Headers and Wire Housings; Device Class L3: unset; Digikey Description: CONN HEADER VERT 2POS 2.54MM; Footprint Url: http://suddendocs.samtec.com/prints/tsw-xxx-xx-x-x-xx-xxx-footprint.pdf; Height: 8.71mm; Lead Free: Yes; Library Name: Altium Content Vault; Manufacturer Part Number: TSW-102-07-G-S; Mouser Description: Conn Unshrouded Header HDR 2 POS 2.54mm Solder ST Thru-Hole Automotive Bulk; Number Of Rows: 1; Octopart: https://octopart.com/tsw-102-07-g-s-samtec-272197; Package: TSW-102-07-G-S; Pitch: 2.54mm; RoHS: TRUE; Temperature: -55°C to +125°C; Temperature Range High: +125°C; Temperature Range Low: -55°C
LS1 = CMP-12433-000001-1: MAGNETIC BUZZER AND TRANSDUCER - More Details; Manufacturer: Multicomp Pro; Current: 30mA; Frequency: 2300Hz; Max Supply Voltage: 5V; Min Supply Voltage: 2V; Operating Supply Voltage: 3V; Standoff Height: 0.5mm; Automotive: No; Category: Speak; Component Kind: Standard; ComponentLink2Description: Datasheet; ComponentLink2URL: http://www.farnell.com/datasheets/1498149.pdf?_ga=2.17855225.1157923166.1572356775-536200964.1569240579; Device Class L1: Electromechanical; Device Class L2: Audio Products; Device Class L3: Buzzers; Height: 9.5mm; Lead Free: Yes; Library Name: Altium Content Vault; Manufacturer Part Number: MCKPX-G1203A-K4056; Octopart: https://octopart.com/mckpx-g1203a-k4056-multicomp-12157154; Package: 0; RoHS: TRUE; Temperature: -20°C to +70°C; Temperature Range High: +70°C; Temperature Range Low: -20°C
P1 = CMP-1502-01064-3: NexarPartId: 32855522; Connector Type: Male Pin; Contact Resistance: 20mΩ; Current Rating: 3A; Gender: Male; Number of Contacts: 4; Operating Supply Voltage: 250V; Pitch: 2.54mm; Color: Black; Component Kind: Standard; Contact Material: Copper; Contact Mating Length: 5.9944mm; Contact Plating: Gold; Height: 8.89mm; Lead Free: Lead Free; Lead Pitch: 2.54mm; Library Name: Altium Content Vault; Max Operating Temperature: 105°C; Min Operating Temperature: -40°C; Mount: Through Hole; Number of Pins: 4; Number of Positions: 4; Number of Rows: 1; Orientation: Vertical; Packaging: Bulk; REACH SVHC: Unknown; RoHS: Compliant; Termination: Solder; Voltage Rating: 250V
P3 = CMP-1712-00002-6: NexarPartId: 20081794; Connector Type: Male Pin; Contact Resistance: 20mΩ; Current Rating: 3A; Gender: Male; Number of Contacts: 2; Operating Supply Voltage: 250V; Pitch: 2.54mm; Color: Black; Component Kind: Standard; Contact Gender: Male; Contact Material: Copper; Contact Mating Length: 5.9944mm; Contact Plating: Gold; Height: 8.94mm; Housing Material: PBT; Lead Free: Lead Free; Lead Pitch: 2.54mm; Library Name: Altium Content Vault; Max Operating Temperature: 125°C; Min Operating Temperature: -40°C; Mount: Through Hole; Number of Pins: 2; Number of Positions: 2; Number of Rows: 1; Orientation: Vertical; Packaging: Bulk; REACH SVHC: No SVHC; RoHS: Compliant; Termination: Through Hole; Voltage Rating: 250V
Q1-Q5 = CMP-2000-06249-1: N-Channel Specified PowerTrench(R) MOSFET, 2.5 V, 1.7 A, -55 to 150 degC, 3-Pin SSOT3L, RoHS, Tape and Reel; Manufacturer: FairchildSemiconductor; Channel Mode: Enhancement; Channel Type: N; Maximum Continuous Drain Current: 1.7A; Maximum Drain Source Voltage: 20V; Maximum Gate Source Voltage: ±8V; Package Version: Rev. 3, 05/2015; Rad Hard: No; RDS-on: 0.07@4.5VOhm; Typical Fall Time: 3ns; Typical Rise Time: 8.5ns; Typical Turn-Off Delay Time: 11ns; Typical Turn-On Delay Time: 5ns; Category: MOSFET; Component Kind: Standard; Datasheet URL: https://www.fairchildsemi.com/datasheets/FD/FDN335N.pdf; Datasheet Version: Rev. C, 04/1999; Library Name: Altium Content Vault; Manufacturer URL: https://www.fairchildsemi.com/; Mounting: SurfaceMount; Mounting Technology: SurfaceMount; Operating Temperature: -55to150°C; Package: 3SuperSOT; Package Description: 3-Pin Small Outline Transistor, Body 2.92 x 1.4 mm, Pitch 0.95 mm; Package Reference: SSOT3L; Package URL: https://www.fairchildsemi.com/package-drawings/MA/MA03B.pdf; Packaging: Tape&Reel
R1 R3 R10 R13 R_BT1 R_BT2 R_BUZZ R_DBL1 R_DBL2 R_HP1-R_HP4 R_IR1-R_IR3 R_IR7-R_IR10 R_TX1 R_TX2 RB0 RB1 = CMP-03211-003506-1: RES SMD 10KΩ 0.5% 1/16W 0603; Manufacturer: TE Connectivity; Manufacturer Part Number: CPF0603D10KE; Power Rating: 0.063W; Temperature Coefficient: 25ppm/°C; Voltage Rating: 50V; Automotive: No; Category: Res; Component Kind: Standard; ComponentLink2Description: Datasheet; ComponentLink2URL: https://www.te.com/commerce/DocumentDelivery/DDEController?Action=showdoc&DocId=Data+Sheet%7F1773200%7FM%7Fpdf%7FEnglish%7FENG_DS_1773200_M.pdf%7F3-1676481-2; Composition: Thin Film; Device Class L1: Passive Components; Device Class L2: Resistors; Device Class L3: Chip SMD Resistors; Height: 0.55mm; Ipc Land Pattern Name: RESC15580X45; Lead Free: Yes; Library Name: Altium Content Vault; Octopart: https://octopart.com/cpf0603d10ke-te+connectivity-22737789; octopart_url: https://octopart.com/cpf0603d10ke-te+connectivity-22737789; Package: CPF0603; packaging: 5k Reel; Resistance: 10KΩ; RoHS: TRUE; Series: CPF; Temperature: -55°C to +155°C; Temperature Range High: +155°C; Temperature Range Low: -55°C; Tolerance: 0.5%; Value: 10KΩ
R5 R7 R8 R33 = CMP-02407-004732-1: RES Thick Film, 2.49Ω, 1%, 0.125W, 200ppm/°C, 0805; Max Power Dissipation: 125mW; Resistance: 2.49R; Voltage Rating: 150V; Case Code (Imperial): 0805; Case Code (Metric): 2012; Case/Package: 0805; Component Kind: Standard; Composition: Thick Film; Contact Plating: Tin; Features: Automotive AEC-Q200; Height: 0.02inch; Lead Free: Lead Free; Length: 0.079inch; Library Name: Altium Content Vault; Max Operating Temperature: 155°C; Min Operating Temperature: -55°C; Number of Terminations: 2; Package Quantity: 5000; Packaging: Tape and Reel; Power Rating: 125mW; Radiation Hardening: No; Temperature Coefficient: 200ppm/°C; Termination: SMD/SMT; Tolerance: 1%; Voltage Rating (DC): 150V; Weight: 0.000194oz; Width: 1.25mm
R11 = CMP-2003-02213-1: Manufacturer: Vishay Dale; Part Number: CRCW120647K0FKEA; Component Kind: Standard; Library Name: Altium Content Vault
R12 = CMP-2003-04372-1: Manufacturer: Vishay Dale; Part Number: WSL1206R2000FEA; Component Kind: Standard; Library Name: Altium Content Vault
R14 R15 = CMP-250906-256246-1: RES Thin Film,  196kΩ, 0.1%, 0.125W, 25ppm/°C, 0805; Component Kind: Standard; Library Name: Altium Content Vault
R_IR4-R_IR6 = CMP-2001-04915-1: Manufacturer: Vishay Dale; Part Number: CRCW080510R0FKEA; Component Kind: Standard; Library Name: Altium Content Vault
SW0 = CMP-1696-00002-1: Slide Switch, 500 V, -20 to 70 degC, 3-Pin THD, RoHS; Case\Package: SOT-23-5; Dropout Voltage: 300 mV; Max Input Voltage: 8 V; Max Ouput Current: 150 mA; Max Power Dissipation: 250 mW; Min Input Voltage: 4.3 V; Nominal Output Voltage: 3.3 V; Outputs: 1; Component Kind: Standard; ComponentLink1Description: Manufacturer URL; ComponentLink1URL: http://www.e-switch.ch/en/; ComponentLink2Description: Datasheet; ComponentLink2URL: https://www.e-switch.com/system/asset/product_line/data_sheet/119/EG.pdf; DatasheetVersion: Oct-15; Height: 1.3 mm; Length: 3 mm; Library Name: Altium Content Vault; Max Operating Temperature: 85 degC; Min Operating Temperature: -40 degC; Mount: Surface Mount; Output Current: 150 mA; Output Voltage: 3.3 V; Package Quantity: 3000; PackageDescription: 3-Pin Through Hole Device, Body 11.6 x 4 mm, Pitch 2.5 mm; PackageReference: EG1218; Packaging: Tape and Reel; Pins: 5; Power Rating: 250 mW; Radiation Hardening: No; REACH SVHC: No SVHC; RoHSCompliant: true; Width: 1.8 mm
U1 = CMP-031-00007-1: ARMÂ® CortexÂ®-M4 STM32F4 Microcontroller IC 32-Bit Single-Core 168MHz 1MB (1M x 8) FLASH 64-LQFP (10x10); MF: STMicroelectronics; Case/Package: LQFP-64 STMicroelectronics; Check_prices: https://www.snapeda.com/parts/STM32F405RGT6/STMicroelectronics/view-part/?ref=eda; Component Kind: Standard; Component Type: Standard; Design Item ID: STM32F405RGT6; MP: STM32F405RGT6; Pins: 64; SnapEDA_Link: https://www.snapeda.com/parts/STM32F405RGT6/STMicroelectronics/view-part/?ref=snap; Source: STM32F405RGT6.SchLib; Library Name: Nguyen Hai Son
U2 = CMP-0062-01631-4: 800mA Low-Dropout Linear Regulator, 4-pin SOT-223, Pb-Free; Manufacturer: Texas Instruments; CompType: LDO IC; High-Reliability: Y; PackageTopMarking: XYTT*N05B; PowerWise: N; Code_IPC: SOT223-4; Code_JEDEC: TO-261-AA; Component Kind: Standard; ComponentLink1Description: Datasheet URL; ComponentLink1URL: http://www.ti.com/general/docs/lit/getliterature.tsp?genericPartNumber=LM1117-N&fileType=pdf; Library Name: Altium Content Vault; PackageDescription: Molded SOT-223, 6.50 x 3.56 x 1.6mm Body, 4 Lead, 2.3mm Pitch; PackageReference: DCY0004A; PartNumber: LM1117IMP-3.3/NOPB; PinCount: 4; RoHS: Y
U3 = CMP-031-00008-1: Buck Switching Regulator IC Positive Adjustable 0.925V 1 Output 3A 8-SOIC (0.154, 3.90mm Width) Exposed Pad; MF: Monolithic Power; Availability: In Stock; Case/Package: SOIC-8 Monolithic Power; Check_prices: https://www.snapeda.com/parts/MP2307DN-LF-Z/Monolithic+Power+Systems+Inc./view-part/?ref=eda; Component Kind: Standard; Component Type: Standard; Design Item ID: MP2307DN-LF-Z; MP: MP2307DN-LF-Z; Pins: 9; Price: None; Purchase-URL: https://pricing.snapeda.com/search/part/MP2307DN-LF-Z/?ref=eda; SnapEDA_Link: https://www.snapeda.com/parts/MP2307DN-LF-Z/Monolithic+Power+Systems+Inc./view-part/?ref=snap; Source: MP2307DN-LF-Z.SchLib; Library Name: Nguyen Hai Son
U4 = CMP-0062-01640-3: 800mA Low-Dropout Linear Regulator, 4-pin SOT-223; Manufacturer: National Semiconductor; CompType: LDO IC; High-Reliability: Y; PackageTopMarking: XYTT*N06A; PowerWise: N; Code_IPC: SOT223-4; Code_JEDEC: TO-261-AA; Component Kind: Standard; ComponentLink1Description: Manufacturer URL; ComponentLink1URL: http://www.national.com/; ComponentLink2Description: LM1117 Datasheet; ComponentLink2URL: http://www.national.com/ds/LM/LM1117.pdf; ComponentLink3Description: LM1117 Web Page; ComponentLink3URL: http://www.national.com/pf/LM/LM1117.html; ComponentLink4Description: MP04A Package Page; ComponentLink4URL: http://www.national.com/packaging/folders/mp04a.html; DatasheetVersion: Apr-2006; Library Name: Altium Content Vault; PackageDescription: Molded SOT-223, 6.50 x 3.56 x 1.6mm Body, 4 Lead, 2.3mm Pitch; PackageReference: MP04A; PackageVersion: revB, Apr-2003; PartNumber: LM1117MP-5.0; PinCount: 4; RevisionNote: SCH symbol layout adjusted; RoHS: N
U5 = CMP-1529-00004-3: IC MOTOR DRIVER PAR 16HTSSOP; Fault Protection: Over Temperature; Interface: PWM; Max Output Current: 2A; Max Supply Voltage: 10.8V; Min Supply Voltage: 2.7V; Nominal Supply Current: 3mA; Number of Outputs: 2; Output Current: 1.5A; Case/Package: HTSSOP; Component Kind: Standard; Lead Free: Lead Free; Library Name: Altium Content Vault; Max Operating Temperature: 85°C; Min Operating Temperature: -40°C; Number of Pins: 16; Output Current per Channel: 1.5A; Package Quantity: 2000; Packaging: Tape and Reel; Radiation Hardening: No; REACH SVHC: No SVHC; RMS Current (Irms): 1.5A; RoHS Compliant: Yes; Series: DRV8833; Supply Current: 3mA; Technology: NMOS
U6 = BMG250: Gyroscope X _Pitch_, Y _Roll_, Z _Yaw_ Â±125, 250, 500, 1000, 2000 25Hz ~ 3200Hz IÂ²C, SPI; MF: Bosch; Availability: Unavailable; Component Kind: Standard; Library Name: BMG250.IntLib; MP: BMG250; Package: LGA-14 Bosch Tools; Price: None; SnapEDA_Link: https://www.snapeda.com/parts/BMG250/Bosch%20Sensortec/view-part/627823/?ref=snap
Y1 = CMP-27762-010110-1: CRYSTAL 16.0000MHZ 4PF SMD; ESR (Equivalent Series Resistance): 100R; Frequency Stability: 10ppm; Case/Package: SMD/SMT; Component Kind: Standard; Frequency: 16MHz; Frequency Tolerance: 10ppm; Library Name: Altium Content Vault; Load Capacitance: 4pF; Max Operating Temperature: 70°C; Min Operating Temperature: -20°C; Mount: Surface Mount; Packaging: Tape and Reel; RoHS Compliant: Yes
